# Altium schematic sheet Processor.SchDoc
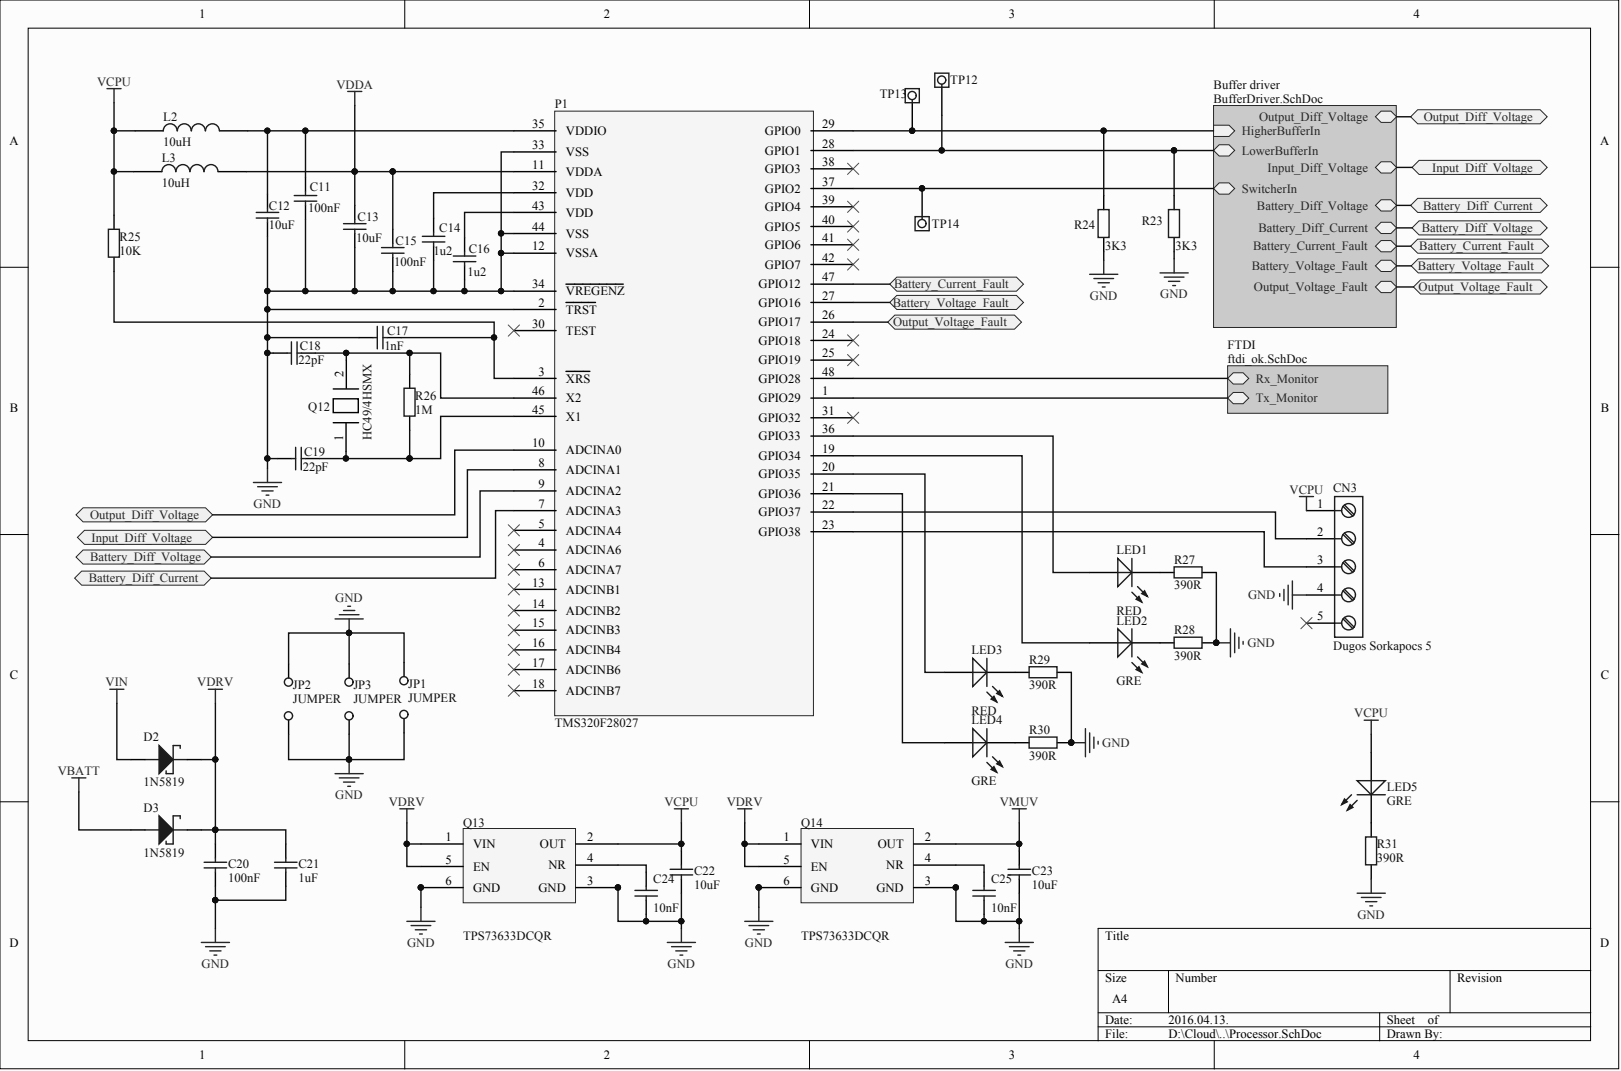
[diagram p0: Processor.SchDoc]

## Parts
C11  100nF  [FP_0805_CAP_085]
C12  10uF  [FP_0805_CAP_085]
C13  10uF  [FP_0805_CAP_085]
C14  1u2  [FP_1206_CAP_125]
C15  100nF  [FP_0805_CAP_085]
C16  1u2  [FP_1206_CAP_125]
C17  1nF  [FP_0805_CAP_085]
C18  22pF  [FP_0805_CAP_085]
C19  22pF  [FP_0805_CAP_085]
C20  100nF  [FP_0805_CAP_085]
C21  1uF  [FP_0805_CAP_085]
C22  10uF  [FP_0805_CAP_085]
C23  10uF  [FP_0805_CAP_085]
C24  10nF  [FP_0805_CAP_085]
C25  10nF  [FP_0805_CAP_085]
CN3  Dugos Sorkapocs 5  [FP_CONN4_TUSKE_254MM]
D2  1N5819  [AXIAL-0.3]
D3  1N5819  [AXIAL-0.3]
JP1  JUMPER  [FP_CONN2_TUSKE_254MM]
JP2  JUMPER  [FP_CONN2_TUSKE_254MM]
JP3  JUMPER  [FP_CONN2_TUSKE_254MM]
L2  10uH  [AXIAL-0.3]
L3  10uH  [AXIAL-0.3]
LED1  RED  [FP_LED_TH_RED]
LED2  GRE  [FP_LED_TH_GREEN]
LED3  RED  [FP_LED_TH_RED]
LED4  GRE  [FP_LED_TH_GREEN]
LED5  GRE  [FP_LED_TH_GREEN]
P1  TMS320F28027  [S-PQFP48]
Q12  HC49/4HSMX  [Crystal_10MHz]
Q13  TPS73633DCQR  [TPS73633]
Q14  TPS73633DCQR  [TPS73633]
R23  3K3  [FP_0805_RES]
R24  3K3  [FP_0805_RES]
R25  10K  [FP_0805_RES]
R26  1M  [FP_0805_RES]
R27  390R  [AXIAL-0.3]
R28  390R  [AXIAL-0.3]
R29  390R  [AXIAL-0.3]
R30  390R  [AXIAL-0.3]
R31  390R  [AXIAL-0.3]
TP12  Testpoint  [TESTPOINT_1.5]  (value not drawn)  (no BOM)
TP13  Testpoint  [TESTPOINT_1.5]  (value not drawn)  (no BOM)
TP14  Testpoint  [TESTPOINT_1.5]  (value not drawn)  (no BOM)

## Ports
BATTERY_CURRENT_FAULT, BATTERY_DIFF_CURRENT, BATTERY_DIFF_VOLTAGE, BATTERY_VOLTAGE_FAULT, INPUT_DIFF_VOLTAGE, OUTPUT_DIFF_VOLTAGE, OUTPUT_VOLTAGE_FAULT

## Nets
GND: C11.1 C12.1 C13.1 C14.1 C15.2 C16.1 C17.1 C18.1 C19.1 C20.1 C21.1 C22.1 C23.1 C24.1 C25.1 CN3.4 JP1.1 JP1.2 JP2.1 JP2.2 JP3.1 JP3.2 P1.2(TRST) P1.12(VSSA) P1.33(VSS) P1.34(VREGENZ) P1.44(VSS) Q13.3(GND) Q13.6(GND) Q14.3(GND) Q14.6(GND) R23.2 R24.1 R27.1 R28.1 R29.1 R30.1 R31.1
VBATT: D3.2
VCPU: C22.2 CN3.1 L2.1 L3.1 LED5.1 Q13.2(OUT) R25.2
VDDA: C13.2 C15.1 L3.2 P1.11(VDDA)
VDRV: C20.2 C21.2 D2.1 D3.1 Q13.1(VIN) Q13.5(EN) Q14.1(VIN) Q14.5(EN)
VIN: D2.2
VMUV: C23.2 Q14.2(OUT)
NetC11_2: C11.2 C12.2 L2.2 P1.35(VDDIO)
NetC14_2: C14.2 P1.32(VDD)
NetC16_2: C16.2 P1.43(VDD)
NetC17_2: C17.2 P1.3(XRS) R25.1
NetC18_2: C18.2 P1.46(X2) Q12.2 R26.2
NetC19_2: C19.2 P1.45(X1) Q12.1 R26.1
NetC24_2: C24.2 Q13.4(NR)
NetC25_2: C25.2 Q14.4(NR)
NetCN3_2: CN3.2 P1.22(GPIO37)
NetCN3_3: CN3.3 P1.23(GPIO38)
NetCN3_5: CN3.5
NetLED1_1: LED1.1 P1.36(GPIO33)
NetLED1_2: LED1.2 R27.2
NetLED2_1: LED2.1 P1.19(GPIO34)
NetLED2_2: LED2.2 R28.2
NetLED3_1: LED3.1 P1.20(GPIO35)
NetLED3_2: LED3.2 R29.2
NetLED4_1: LED4.1 P1.21(GPIO36)
NetLED4_2: LED4.2 R30.2
NetLED5_2: LED5.2 R31.2
NetP1_1: P1.1(GPIO29)
NetP1_4: P1.4(ADCINA6)
NetP1_5: P1.5(ADCINA4)
NetP1_6: P1.6(ADCINA7)
NetP1_7: P1.7(ADCINA3) ; port BATTERY_DIFF_CURRENT
NetP1_8: P1.8(ADCINA1) ; port INPUT_DIFF_VOLTAGE
NetP1_9: P1.9(ADCINA2) ; port BATTERY_DIFF_VOLTAGE
NetP1_10: P1.10(ADCINA0) ; port OUTPUT_DIFF_VOLTAGE
NetP1_13: P1.13(ADCINB1)
NetP1_14: P1.14(ADCINB2)
NetP1_15: P1.15(ADCINB3)
NetP1_16: P1.16(ADCINB4)
NetP1_17: P1.17(ADCINB6)
NetP1_18: P1.18(ADCINB7)
NetP1_24: P1.24(GPIO18)
NetP1_25: P1.25(GPIO19)
NetP1_26: P1.26(GPIO17) ; port OUTPUT_VOLTAGE_FAULT
NetP1_27: P1.27(GPIO16) ; port BATTERY_VOLTAGE_FAULT
NetP1_28: P1.28(GPIO1) R23.1 TP12.1
NetP1_29: P1.29(GPIO0) R24.2 TP13.1
NetP1_30: P1.30(TEST)
NetP1_31: P1.31(GPIO32)
NetP1_37: P1.37(GPIO2) TP14.1
NetP1_38: P1.38(GPIO3)
NetP1_39: P1.39(GPIO4)
NetP1_40: P1.40(GPIO5)
NetP1_41: P1.41(GPIO6)
NetP1_42: P1.42(GPIO7)
NetP1_47: P1.47(GPIO12) ; port BATTERY_CURRENT_FAULT
NetP1_48: P1.48(GPIO28)

## Library data of the parts
C11-C25 = CAP: Capacitor; Component Kind: Standard; Signal Integrity: Cap; Simulation: CAP; Library Name: hallglib.SchLib
  C11 C15 C20: Lomex NR: 82-07-32; Value: 100nF
  C12 C13 C22 C23: Lomex NR: 82-08-32; Value: 10uF
  C14 C16: Lomex NR: 82-05-93; Value: 1u2
  C17: Lomex NR: 82-00-75; Value: 1nF
  C18 C19: Lomex NR: 82-09-18; Value: 22pF
  C21: Lomex NR: 82-05-11; Value: 1uF
  C24 C25: Lomex NR: 82-05-66; Value: 10nF
CN3 = Dugos Sorkapocs 5: SORKAPOCS 5 P RM5.08 CSAPSORLÉC 90° ZÁRT 12A; K_manufacturer: (STE); Part Class: Connector; CompType: CPM5.08/5SQ, CPF5.08/5; Order Code: 43-07-79, 43-07-78; Value: 5P; Component Kind: Standard; Distributor: Lomex; Package: RM5.08; Library Name: MERES.SchLib
D2 D3 = SCHOTTKY: NXP - PMEG3020EJ,115 - SCHOTTKY RECT 30V 2A SOD323F; Component Kind: Standard; Supplier: 16-04-12; Type: 1N5819; Library Name: hallglib.SchLib
JP1-JP3 = JUMPER: Jumper Wire; Component Kind: Standard; Supplier: Farnell 1668537×1/6; Library Name: hallglib.SchLib
L2 L3 = IND: Inductor; Component Kind: Standard; Lomex NR: 55-00-65; Value: 10uH; Library Name: hallglib.SchLib
LED1 LED3 = LED: K_Manufacturer: Kingbright; Part Class: Semiconductor; CompType: KP-3216SRD-PRV; Component Kind: Standard; Description: LED; Distributor: Lomex; Order Code: 95-00-28; Package: 1206; Value: RED; Library Name: hallglib.SchLib
LED2 LED4 LED5 = LED: K_Manufacturer: Kingbright; Part Class: Semiconductor; CompType: KP-3216SGD; Component Kind: Standard; Description: LED; Distributor: Lomex; Order Code: 95-00-26; Package: 1206; Value: GRE; Library Name: hallglib.SchLib
P1 = TMS320F28027: Processor; Component Kind: Standard; Library Name: hallglib.SchLib
Q12 = HC49/4HSMX: kristály; Component Kind: Standard; Library Name: hallglib.SchLib
Q13 Q14 = TPS73633DCQR: proci táp 3V3; Component Kind: Standard; Library Name: hallglib.SchLib
R23-R26 = RES: Resistor; Component Kind: Standard; Signal Integrity: Res; Simulation: RESISTOR; Library Name: hallglib.SchLib
  R23 R24: Value: 3K3
  R25: Value: 10K
  R26: Value: 1M
R27-R31 = Resistor: K_Manufacturer: Viking Tech Corp.; CompType: CR-05JL7--390R; Component Kind: Standard; Distributor: Lomex; Order Code: 81-10-82; Package: 0805; Part Class: Resistor; Value: 390R; Library Name: hallglib.SchLib
TP12-TP14 = Testpoint: Part Class: Connector; Library Name: MERES.SchLib
